# Altium schematic sheet CaRe_Schematic_SMD_FRONT_PANEL.SchDoc(CaRe_Schematic_SMD_FRONT_PANEL)
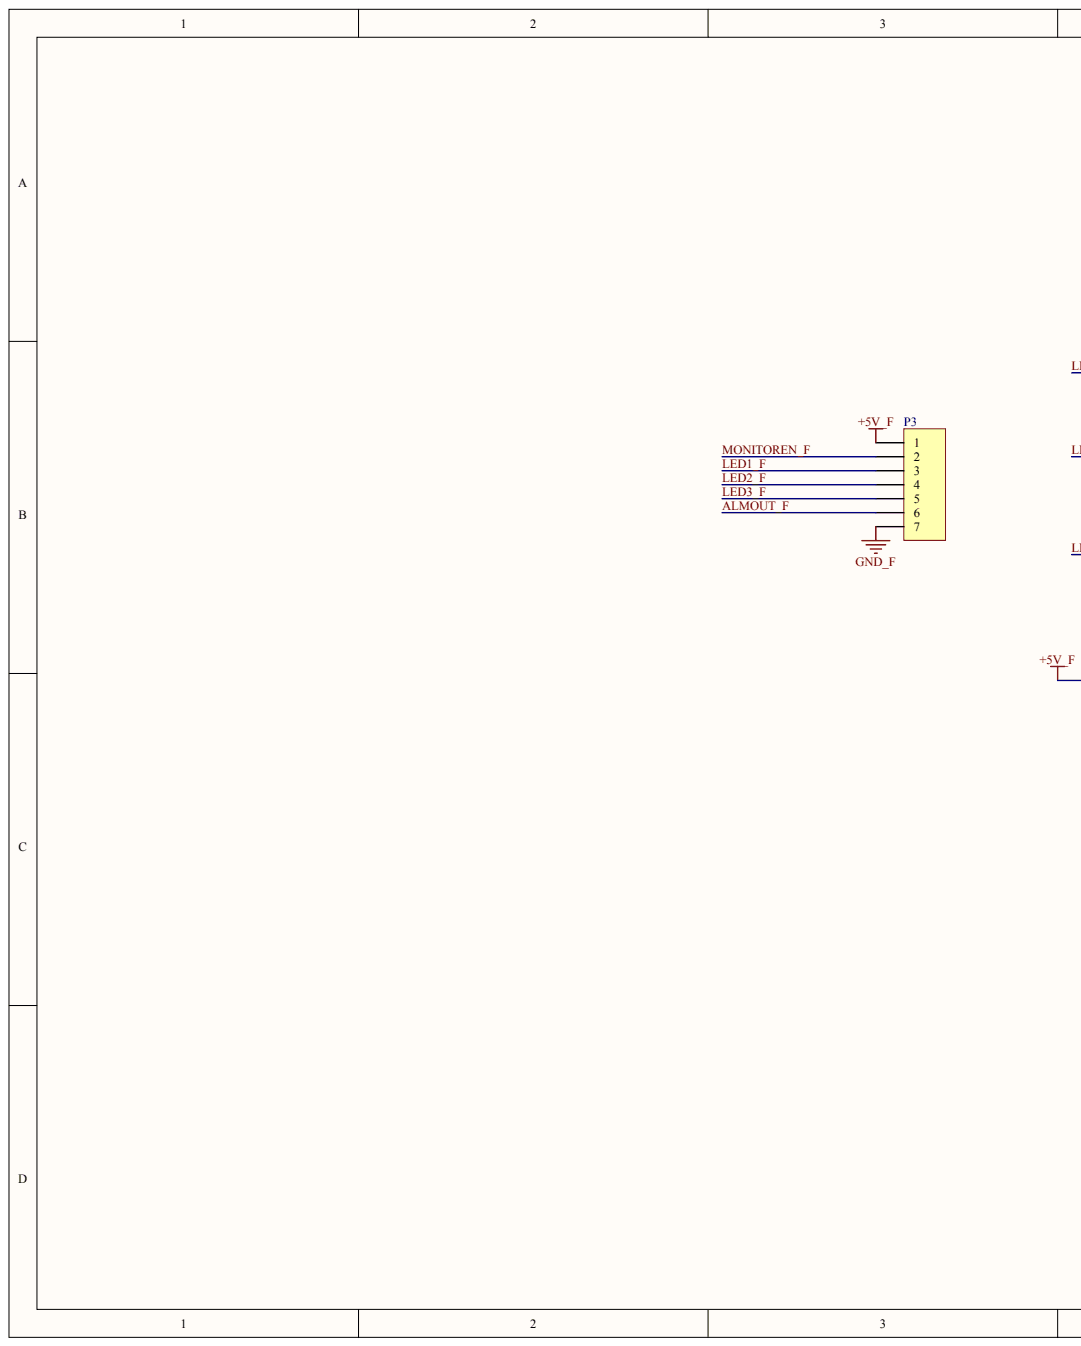
[diagram p0: CaRe_Schematic_SMD_FRONT_PANEL.SchDoc, left]
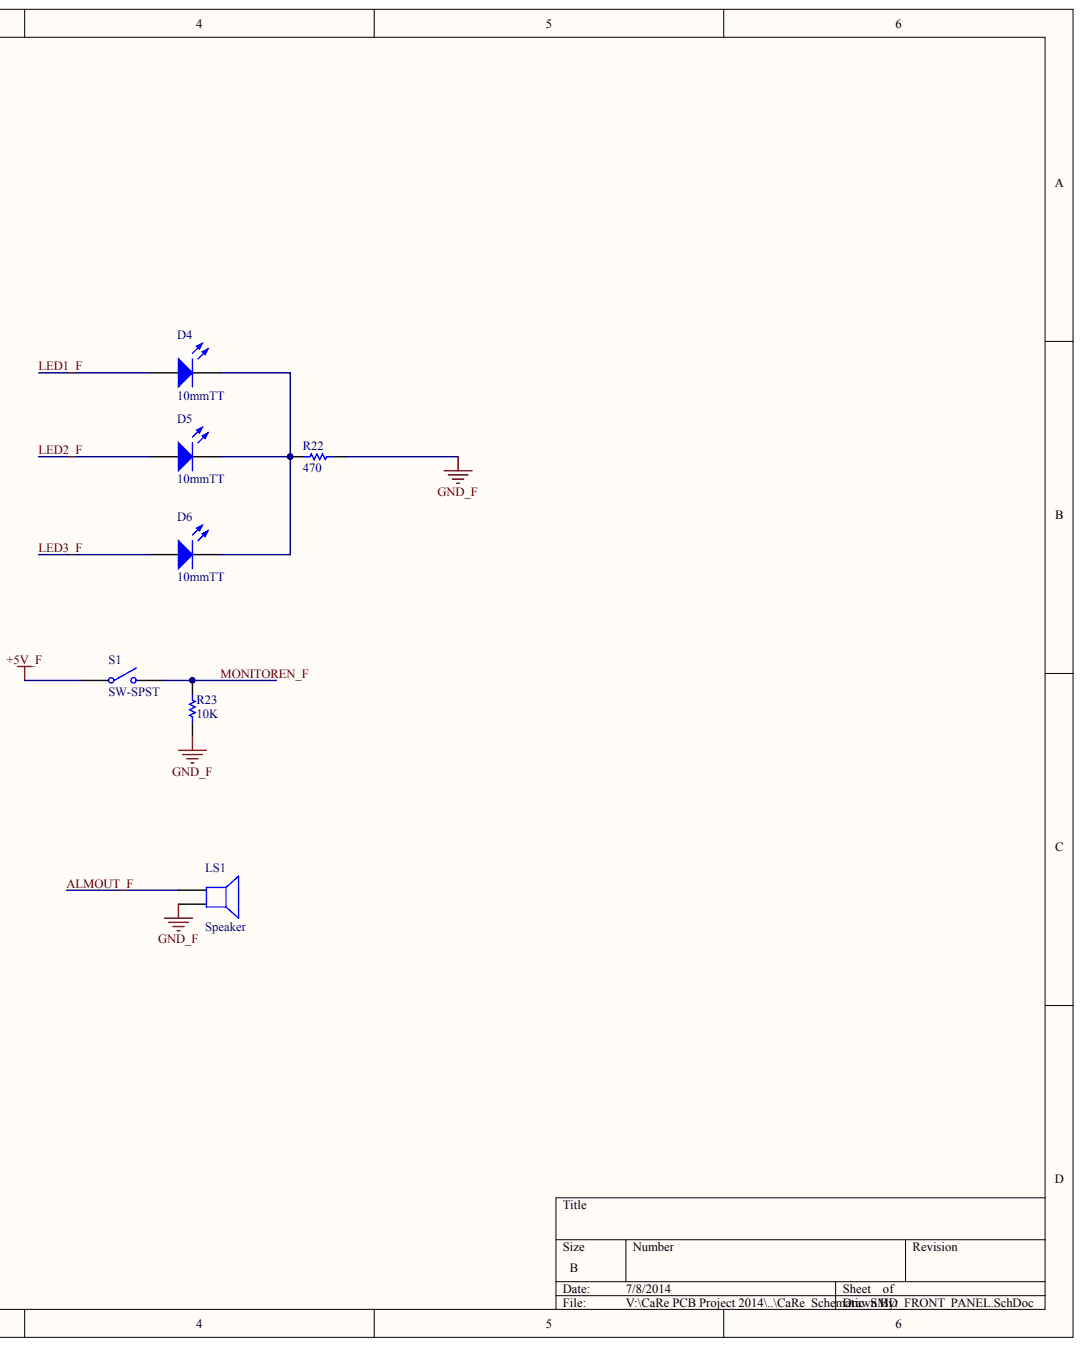
[diagram p1: CaRe_Schematic_SMD_FRONT_PANEL.SchDoc, right]

## Parts
D4  10mmTT
D5  10mmTT
D6  10mmTT
LS1  Speaker
P3  Header 7  (value not drawn)
R22  value 470  (hidden comment: Resistor)
R23  value 10K  (hidden comment: Resistor)
S1  SW-SPST

## Nets
+5V_F: P3.1 S1.1
GND_F: LS1.2 P3.7 R22.2 R23.1
ALMOUT_F: LS1.1 P3.6
LED1_F: D4.1 P3.3
LED2_F: D5.1 P3.4
LED3_F: D6.1 P3.5
MONITOREN_F: P3.2 R23.2 S1.2
NetD4_2: D4.2 D5.2 D6.2 R22.1

## Library data of the parts
D4-D6 = (no symbol name): Part Number: P_100_LTST-C170KRKT; Published: 8-Jun-2000; LatestRevisionDate: 08-Jul-2005; LatestRevisionNote: Mech Layer 1 removed.; PackageReference: LED-1; PackageDescription: LED; 2 Leads; Publisher: Altium Limited
LS1 P3 R22 R23 S1 = (no symbol name): LatestRevisionDate: 17-Jul-2002; LatestRevisionNote: Re-released for DXP Platform.; Publisher: Altium Limited
  LS1: Published: 24-Mar-1999; PackageReference: PIN2
  R22 R23: Published: 8-Jun-2000; PackageReference: AXIAL-0.3; PackageDescription: Axial Device, Thru-Hole; 2 Leads; 0.3 in Pin Spacing
  S1: Published: 24-Mar-1999; PackageReference: SPST-2; PackageDescription: Switch; 2 Leads
